# Altium schematic sheet PIC32_Subsystem.SchDoc
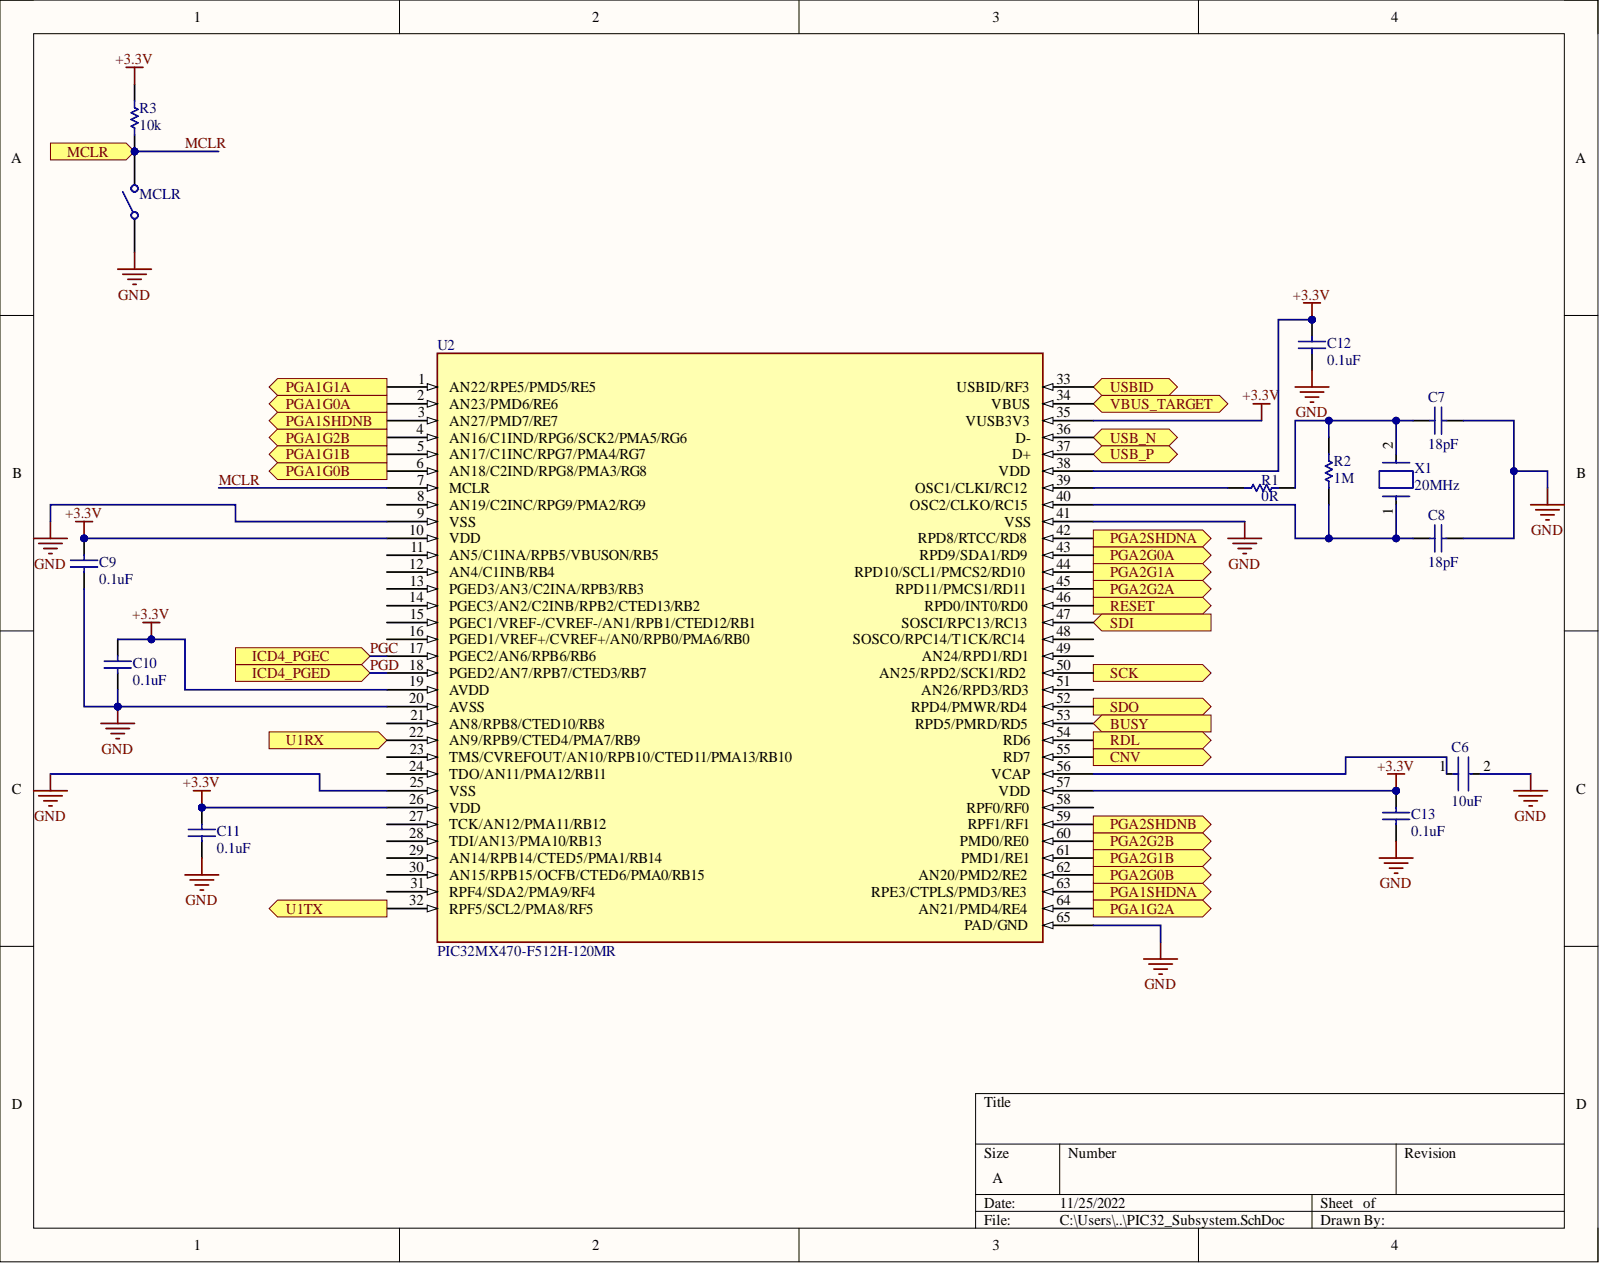
[diagram p0: PIC32_Subsystem.SchDoc]

## Parts
C6  10uF  [FP-GRM188-0_1-e0_2_0_55-IPC_A]
C7  18pF  [CAPC1005X55X25LL05T10]
C8  18pF  [CAPC1005X55X25LL05T10]
C9  0.1uF  [CAPC1050X55X23ML05T13]
C10  0.1uF  [CAPC1050X55X23ML05T13]
C11  0.1uF  [CAPC1050X55X23ML05T13]
C12  0.1uF  [CAPC1050X55X23ML05T13]
C13  0.1uF  [CAPC1050X55X23ML05T13]
MCLR  434121043816  [434121043816]  (value not drawn)
R1  0R  [RESC1005X40X25NL05T10]
R2  1M  [RESC1005X40X25LL05T05]
R3  10k  [RESC1005X40X25LL05T05]
U2  PIC32MX470-F512H-120MR  [QFN50P900X900X100-65N]
X1  20MHz  Load Capacitance: 18pF  [FP-ABM7-MFG]

## Ports
BUSY, CNV, ICD4_PGEC, ICD4_PGED, MCLR, PGA1G0A, PGA1G0B, PGA1G1A, PGA1G1B, PGA1G2A, PGA1G2B, PGA1SHDNA, PGA1SHDNB, PGA2G0A, PGA2G0B, PGA2G1A, PGA2G1B, PGA2G2A, PGA2G2B, PGA2SHDNA, PGA2SHDNB, RDL, RESET, SCK, SDI, SDO, U1RX, U1TX, USB_N, USB_P, USBID, VBUS_TARGET

## Nets
+3.3V: C9.2 C10.2 C11.2 C12.2 C13.2 R3.2 U2.10(VDD) U2.19(AVDD) U2.26(VDD) U2.35(VUSB3V3) U2.38(VDD) U2.57(VDD)
GND: C6.2 C7.2 C8.2 C9.1 C10.1 C11.1 C12.1 C13.1 MCLR.1 U2.9(VSS) U2.20(AVSS) U2.25(VSS) U2.41(VSS) U2.65(PAD/GND)
BUSY: U2.53(RPD5/PMRD/RD5) ; port BUSY
CNV: U2.55(RD7) ; port CNV
MCLR: MCLR.2 R3.1 U2.7(MCLR) ; port MCLR
PGA1G0A: U2.2(AN23/PMD6/RE6) ; port PGA1G0A
PGA1G0B: U2.6(AN18/C2IND/RPG8/PMA3/RG8) ; port PGA1G0B
PGA1G1A: U2.1(AN22/RPE5/PMD5/RE5) ; port PGA1G1A
PGA1G1B: U2.5(AN17/C1INC/RPG7/PMA4/RG7) ; port PGA1G1B
PGA1G2A: U2.64(AN21/PMD4/RE4) ; port PGA1G2A
PGA1G2B: U2.4(AN16/C1IND/RPG6/SCK2/PMA5/RG6) ; port PGA1G2B
PGA1SHDNA: U2.63(RPE3/CTPLS/PMD3/RE3) ; port PGA1SHDNA
PGA1SHDNB: U2.3(AN27/PMD7/RE7) ; port PGA1SHDNB
PGA2G0A: U2.43(RPD9/SDA1/RD9) ; port PGA2G0A
PGA2G0B: U2.62(AN20/PMD2/RE2) ; port PGA2G0B
PGA2G1A: U2.44(RPD10/SCL1/PMCS2/RD10) ; port PGA2G1A
PGA2G1B: U2.61(PMD1/RE1) ; port PGA2G1B
PGA2G2A: U2.45(RPD11/PMCS1/RD11) ; port PGA2G2A
PGA2G2B: U2.60(PMD0/RE0) ; port PGA2G2B
PGA2SHDNA: U2.42(RPD8/RTCC/RD8) ; port PGA2SHDNA
PGA2SHDNB: U2.59(RPF1/RF1) ; port PGA2SHDNB
PGC: U2.17(PGEC2/AN6/RPB6/RB6) ; port ICD4_PGEC
PGD: U2.18(PGED2/AN7/RPB7/CTED3/RB7) ; port ICD4_PGED
RDL: U2.54(RD6) ; port RDL
RESET: U2.46(RPD0/INT0/RD0) ; port RESET
SCK: U2.50(AN25/RPD2/SCK1/RD2) ; port SCK
SDI: U2.47(SOSCI/RPC13/RC13) ; port SDI
SDO: U2.52(RPD4/PMWR/RD4) ; port SDO
U1RX: U2.22(AN9/RPB9/CTED4/PMA7/RB9) ; port U1RX
U1TX: U2.32(RPF5/SCL2/PMA8/RF5) ; port U1TX
USB_N: U2.36(D-) ; port USB_N
USB_P: U2.37(D+) ; port USB_P
USBID: U2.33(USBID/RF3) ; port USBID
VBUS_TARGET: U2.34(VBUS) ; port VBUS_TARGET
NetC6_1: C6.1 U2.56(VCAP)
NetC7_1: C7.1 R1.2 R2.2 X1.2
NetC8_1: C8.1 R2.1 U2.40(OSC2/CLKO/RC15) X1.1
NetR1_1: R1.1 U2.39(OSC1/CLKI/RC12)

Not connected: U2 8(AN19/C2INC/RPG9/PMA2/RG9) 11(AN5/C1INA/RPB5/VBUSON/RB5) 12(AN4/C1INB/RB4) 13(PGED3/AN3/C2INA/RPB3/RB3) 14(PGEC3/AN2/C2INB/RPB2/CTED13/RB2) 15(PGEC1/VREF-/CVREF-/AN1/RPB1/CTED12/RB1) 16(PGED1/VREF+/CVREF+/AN0/RPB0/PMA6/RB0) 21(AN8/RPB8/CTED10/RB8) 23(TMS/CVREFOUT/AN10/RPB10/CTED11/PMA13/RB10) 24(TDO/AN11/PMA12/RB11) 27(TCK/AN12/PMA11/RB12) 28(TDI/AN13/PMA10/RB13) 29(AN14/RPB14/CTED5/PMA1/RB14) 30(AN15/RPB15/OCFB/CTED6/PMA0/RB15) 31(RPF4/SDA2/PMA9/RF4) 48(SOSCO/RPC14/T1CK/RC14) 49(AN24/RPD1/RD1) 51(AN26/RPD3/RD3) 58(RPF0/RF0)

## Library data of the parts
C6 = CMP-2006-02792-2: Chip Multilayer Ceramic Capacitors for General Purpose, 0603, 10uF, X5R, 15%, 20%, 6.3V  GRM188R60J106ME47D; Capacitance: 10uF; Case Code (Imperial): 0603; Case Code (Metric): 1608; Case/Package: 0603; Component Kind: Standard; Depth: 0.8mm; Dielectric: X5R; Height: 0.8mm; Lead Free: Lead Free; Length: 1.6mm; Library Name: Altium Content Vault; Material: Ceramic; Max Operating Temperature: 85°C; Min Operating Temperature: -55°C; Mount: Surface Mount; Package Quantity: 4000; Packaging: Tape and Reel; Radiation Hardening: No; REACH SVHC: No SVHC; Termination: SMD/SMT; Thickness: 0.035inch; Tolerance: 20%; Voltage: 6.3V; Voltage Rating: 6.3V; Voltage Rating (DC): 6.3V; Width: 0.031inch
C7 C8 = CMP-2008-04271-1: GRM1555C1H180GA01D; Manufacturer: Murata; Component Kind: Standard; Library Name: Altium Content Vault; Part Number: GRM1555C1H180GA01D
C9-C13 = CMP-2000-05439-1: Chip Capacitor, 100 nF, +/- 10%, 16 V, -55 to 125 degC, 0402 (1005 Metric), RoHS, Tape and Reel  CL05B104KO5NNNC; Manufacturer: Samsung Electro-Mechanics; Capacitance: 100000pF; Dielectric: X7R; Rated Voltage: 16V; Case Size/Dimension: 0402; Component Kind: Standard; Datasheet URL: https://datasheet.ciiva.com/29198/458718-da-01-en-vs-kondensator-0402-29198423.pdf; Datasheet Version: Nov-10; Library Name: Altium Content Vault; Manufacturer URL: http://www.samsungsem.com/global/index.jsp; Tolerance (%): ±10%
MCLR = CMP-1459-00005-1: WS-TASV SMD Tact Switch 6.0X3.5 mm; Manufacturer: Wuerth Elektronik; NoPins: 02; Component Kind: Standard; ComponentLink1Description: Manufacturer URL; ComponentLink1URL: http://www.we-online.com; ComponentLink2Description: Datasheet; ComponentLink2URL: http://katalog.we-online.de/em/datasheet/434121043816.pdf; Library Name: Altium Content Vault; PackageReference: 434121043816; PartNumber: 434121043816
R1 = CMP-1011-00001-2: RC0402JR-070RL; Manufacturer: Yageo / Phycomp; Component Kind: Standard; Library Name: Altium Content Vault; Part Number: RC0402JR-070RL
R2 = CMP-2002-00609-3: Chip Resistor, 1 MOhm, +/- 1%, 100 mW, -55 to 155 degC, 0402 (1005 Metric), RoHS, Tape and Reel  ERJ-2RKF1004X; Manufacturer: Basic Passives; Case\/Package: 0402; Power Rating: 100 mW; Resistance: 1 MOhm; Voltage Rating: 50 V; Case Code (Imperial): 0402; Case Code (Metric): 1005; Component Kind: Standard; ComponentLink1Description: Manufacturer URL; ComponentLink1URL: http://www.panasonic.com/; ComponentLink2Description: Datasheet; ComponentLink2URL: http://industrial.panasonic.com/cdbs/www-data/pdf/RDA0000/AOA0000C86.pdf; DatasheetVersion: 04/2015; Height: 0.35 mm; Length: 1 mm; Library Name: Altium Content Vault; Max Operating Temperature: 155 degC; Min Operating Temperature: -55 degC; Mount: Surface Mount; Mounting Technology: Surface Mount; Package Quantity: 10000; PackageDescription: 2-Pin Surface Mount Device, Body 1 x 0.5 mm; PackageReference: 0402; Packaging: Tape and Reel; PartNumber: ERJ-2RKF1004X; Pins: 2; Radiation Hardening: No; REACH SVHC: Yes; RoHSCompliant: true; Tolerance: 1%; Weight: 2.8E-05oz; Width: 0.5 mm
R3 = CMP-2000-07588-1: Chip Resistor, 10 KOhm, +/- 1%, 0.1 W, -55 to 155 degC, 0402 (1005 Metric), RoHS, Tape and Reel  ERJ2RKF1002X; Manufacturer: Panasonic; Case\/Package: 0402; Max Power Dissipation: 100mW; Resistance: 10KOhm; Temperature Coefficient: 100ppm\/degreesC; Voltage Rating: 50V; Case Code (Imperial): 0402; Case Code (Metric): 1005; Component Kind: Standard; Composition: ThickFilm; Contact Plating: Tin; Datasheet URL: https://industrial.panasonic.com/cdbs/www-data/pdf/RDA0000/AOA0000C304.pdf; Datasheet Version: 02/2017; Depth: 0.5mm; Height: 0.35mm; Lead Free: LeadFree; Length: 1mm; Library Name: Altium Content Vault; Manufacturer URL: http://www.panasonic.com/; Max Operating Temperature: 155degC; Military Standard: Not; Min Operating Temperature: -55degC; Mount: SurfaceMount; Mounting Technology: SurfaceMount; Number of Pins: 2; Number of Terminations: 2; Package Description: 2-Pin Surface Mount Device, Body 1 x 0.5 mm; Package Quantity: 1; Package Reference: 402; Packaging: TapeandReel; Power Rating: 100mW; Radiation Hardening: No; REACH SVHC: NoSVHC; RoHSCompliant: True; Termination: SMD/SMT; Tolerance: 1%; Voltage Rating (DC): 50V; Width: 0.02inch
X1 = CMP-27762-013587-1: Crystal 20MHz ±20ppm 18pF SMD-2 6mm x 3.5mm  ABM7-20.000MHZ-D2Y-T; ESR (Equivalent Series Resistance): 50R; Frequency Stability: 30ppm; Case/Package: CSMD; Component Kind: Standard; Contact Plating: Gold; Depth: 3.5mm; Frequency: 20MHz; Frequency Tolerance: 20ppm; Height: 0.055inch; Lead Free: Lead Free; Length: 6mm; Library Name: Altium Content Vault; Max Operating Temperature: 85°C; Min Operating Temperature: -40°C; Mount: Surface Mount; Number of Pins: 2; Operating Frequency: 20 MHz; Package Quantity: 1000; Packaging: Tape and Reel; Radiation Hardening: No; Resistor Type: Ceramic; Series: ABM7; Series Resistance: 50R; Termination: SMD/SMT; Tolerance: 20ppm; Width: 0.138inch
U2 = PIC32MX470-F512H-120MR: Component Kind: Standard; Library Name: PIC32MX470-F512H-120MR.SchLib
